# Altium schematic sheet Master.SchDoc
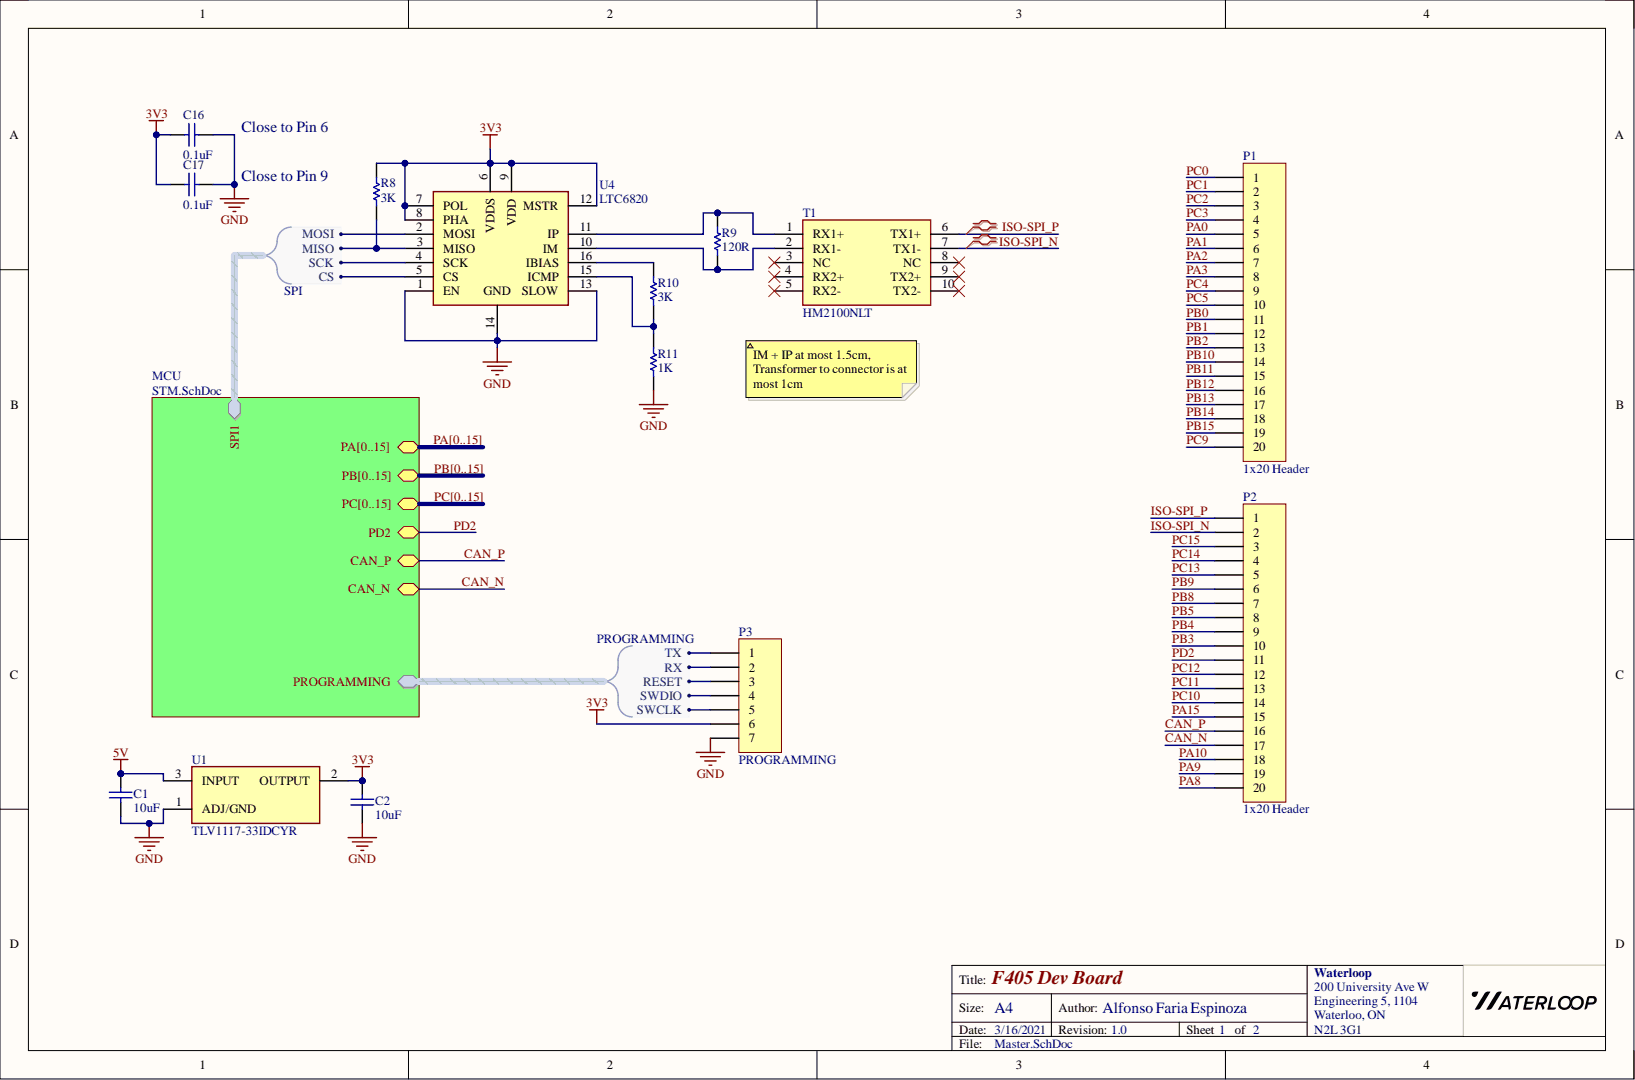
[diagram p0: Master.SchDoc]

## Parts
C1  value 10uF  [0805 - Capacitor]  (hidden comment: Capacitor - Nonpolarized)
C2  value 10uF  [0805 - Capacitor]  (hidden comment: Capacitor - Nonpolarized)
C16  value 0.1uF  [0805 - Capacitor]  (hidden comment: Capacitor - Nonpolarized)
C17  value 0.1uF  [0805 - Capacitor]  (hidden comment: Capacitor - Nonpolarized)
P1  1x20 Header  [HDR1X20]
P2  1x20 Header  [HDR1X20]
P3  PROGRAMMING  [HDR1X7]
R8  value 3K  [0603 - Resistor]  (hidden comment: Resistor)
R9  value 120R  [0603 - Resistor]  (hidden comment: Resistor)
R10  value 3K  [0603 - Resistor]  (hidden comment: Resistor)
R11  value 1K  [0603 - Resistor]  (hidden comment: Resistor)
T1  HM2100NLT  [HM2100NLT]
U1  TLV1117-33IDCYR  [TLV1117]
U4  LTC6820  [LTC6820]

## Nets
3V3: C2.2 C16.2 C17.2 P3.6 R8.2 U1.2 U4.6(VDDS) U4.7(POL) U4.8(PHA) U4.9(VDD) U4.12(MSTR)
5V: C1.2 U1.3
GND: C1.1 C2.1 C16.1 C17.1 P3.7 R11.1 U1.1 U4.1(EN) U4.13(SLOW) U4.14
CAN_N: P2.17
CAN_P: P2.16
ISO-SPI_N: P2.2 T1.7(TX1-)
ISO-SPI_P: P2.1 T1.6(TX1+)
PA0: P1.5  [also labelled PA]
PA1: P1.6  [also labelled PA]
PA2: P1.7  [also labelled PA]
PA3: P1.8  [also labelled PA]
PA8: P2.20  [also labelled PA]
PA9: P2.19  [also labelled PA]
PA10: P2.18  [also labelled PA]
PA15: P2.15  [also labelled PA]
PB0: P1.11  [also labelled PB]
PB1: P1.12  [also labelled PB]
PB2: P1.13  [also labelled PB]
PB3: P2.10  [also labelled PB]
PB4: P2.9  [also labelled PB]
PB5: P2.8  [also labelled PB]
PB8: P2.7  [also labelled PB]
PB9: P2.6  [also labelled PB]
PB10: P1.14  [also labelled PB]
PB11: P1.15  [also labelled PB]
PB12: P1.16  [also labelled PB]
PB13: P1.17  [also labelled PB]
PB14: P1.18  [also labelled PB]
PB15: P1.19  [also labelled PB]
PC0: P1.1  [also labelled PC]
PC1: P1.2  [also labelled PC]
PC2: P1.3  [also labelled PC]
PC3: P1.4  [also labelled PC]
PC4: P1.9  [also labelled PC]
PC5: P1.10  [also labelled PC]
PC9: P1.20  [also labelled PC]
PC10: P2.14  [also labelled PC]
PC11: P2.13  [also labelled PC]
PC12: P2.12  [also labelled PC]
PC13: P2.5  [also labelled PC]
PC14: P2.4  [also labelled PC]
PC15: P2.3  [also labelled PC]
PD2: P2.11
NetP3_1: P3.1
NetP3_2: P3.2
NetP3_3: P3.3
NetP3_4: P3.4
NetP3_5: P3.5
NetR8_1: R8.1 U4.3(MISO)
NetR9_1: R9.1 T1.2(RX1-) U4.10(IM)
NetR9_2: R9.2 T1.1(RX1+) U4.11(IP)
NetR10_1: R10.1 R11.2 U4.15(ICMP)
NetR10_2: R10.2 U4.16(IBIAS)
NetT1_3: T1.3(NC)
NetT1_4: T1.4(RX2+)
NetT1_5: T1.5(RX2-)
NetT1_8: T1.8(NC)
NetT1_9: T1.9(TX2+)
NetT1_10: T1.10(TX2-)
NetU4_2: U4.2(MOSI)
NetU4_4: U4.4(SCK)
NetU4_5: U4.5(CS)
PA4:  [also labelled PA]
PA5:  [also labelled PA]
PA6:  [also labelled PA]
PA7:  [also labelled PA]
PA11:  [also labelled PA]
PA12:  [also labelled PA]
PA13:  [also labelled PA]
PA14:  [also labelled PA]
PB6:  [also labelled PB]
PB7:  [also labelled PB]
PC6:  [also labelled PC]
PC7:  [also labelled PC]
PC8:  [also labelled PC]

## Library data of the parts
C1 C2 C17 = Capacitor - Nonpolarized: Nonpolarized Capacitor; Component Kind: Standard; Library Name: General.SchLib; Signal Integrity: Cap; Simulation: CAP
C16 = Capacitor - Nonpolarized: Nonpolarized Capacitor; Value: 0.1uF; Component Kind: Standard; Library Name: General.SchLib; Signal Integrity: Cap; Simulation: CAP
P1 P2 = 1x20 Header: 1x20 Pin Header; Component Kind: Standard; Library Name: GeneralConnectors.SchLib
P3 = 1x7 Header: 1x7 Pin Header; Component Kind: Standard; Library Name: GeneralConnectors.SchLib; Signal Integrity: Connector
T1 = HM2100NLT: Pulse Transformer 1:1 Surface Mount 150µH, 450µH; Manufacturer: Pulse Electronics; Component Kind: Standard; Datasheet: https://productfinder.pulseeng.com/doc_type/WEB301/doc_num/HM2100NL/doc_part/HM2100NL.pdf; Library Name: GeneralComponents.SchLib; Manufacturer Part Number: HM2100NLT; Supplier: Digikey; Supplier Part Number: 1840-1032-1-ND
U1 = TLV1117-33IDCYR: Linear Voltage Regulator IC 3.3V 800MA SOT223-4; Manufacturer: Texas Instruments; Component Kind: Standard; Datasheet: http://www.ti.com/lit/ds/symlink/tlv1117.pdf?HQS=TI-null-null-digikeymode-df-pf-null-wwe&ts=1590668900314; Library Name: GeneralComponents.SchLib; Manufacturer Part Number: TLV1117-33IDCYR; Supplier: Digikey; Supplier Part Number: 296-21112-1-ND
U4 = LTC6820: Manufacturer: Analog Devices; Manufacturer Part Number: LTC6820IMS#TRPBF; Component Kind: Standard; Library Name: BMS.SchLib; Supplier: Digikey; Supplier Part Number: LTC6820IMS#TRPBFCT-ND
R8-R11 = Resistor: Component Kind: Standard; Description: Resistor; Library Name: General.SchLib; Signal Integrity: Res; Simulation: RESISTOR
